# Altium schematic sheet SSL_Brushless_V3.0.0-Conn.SchDoc(SSL_Brushless_V3.0.0-Conn)
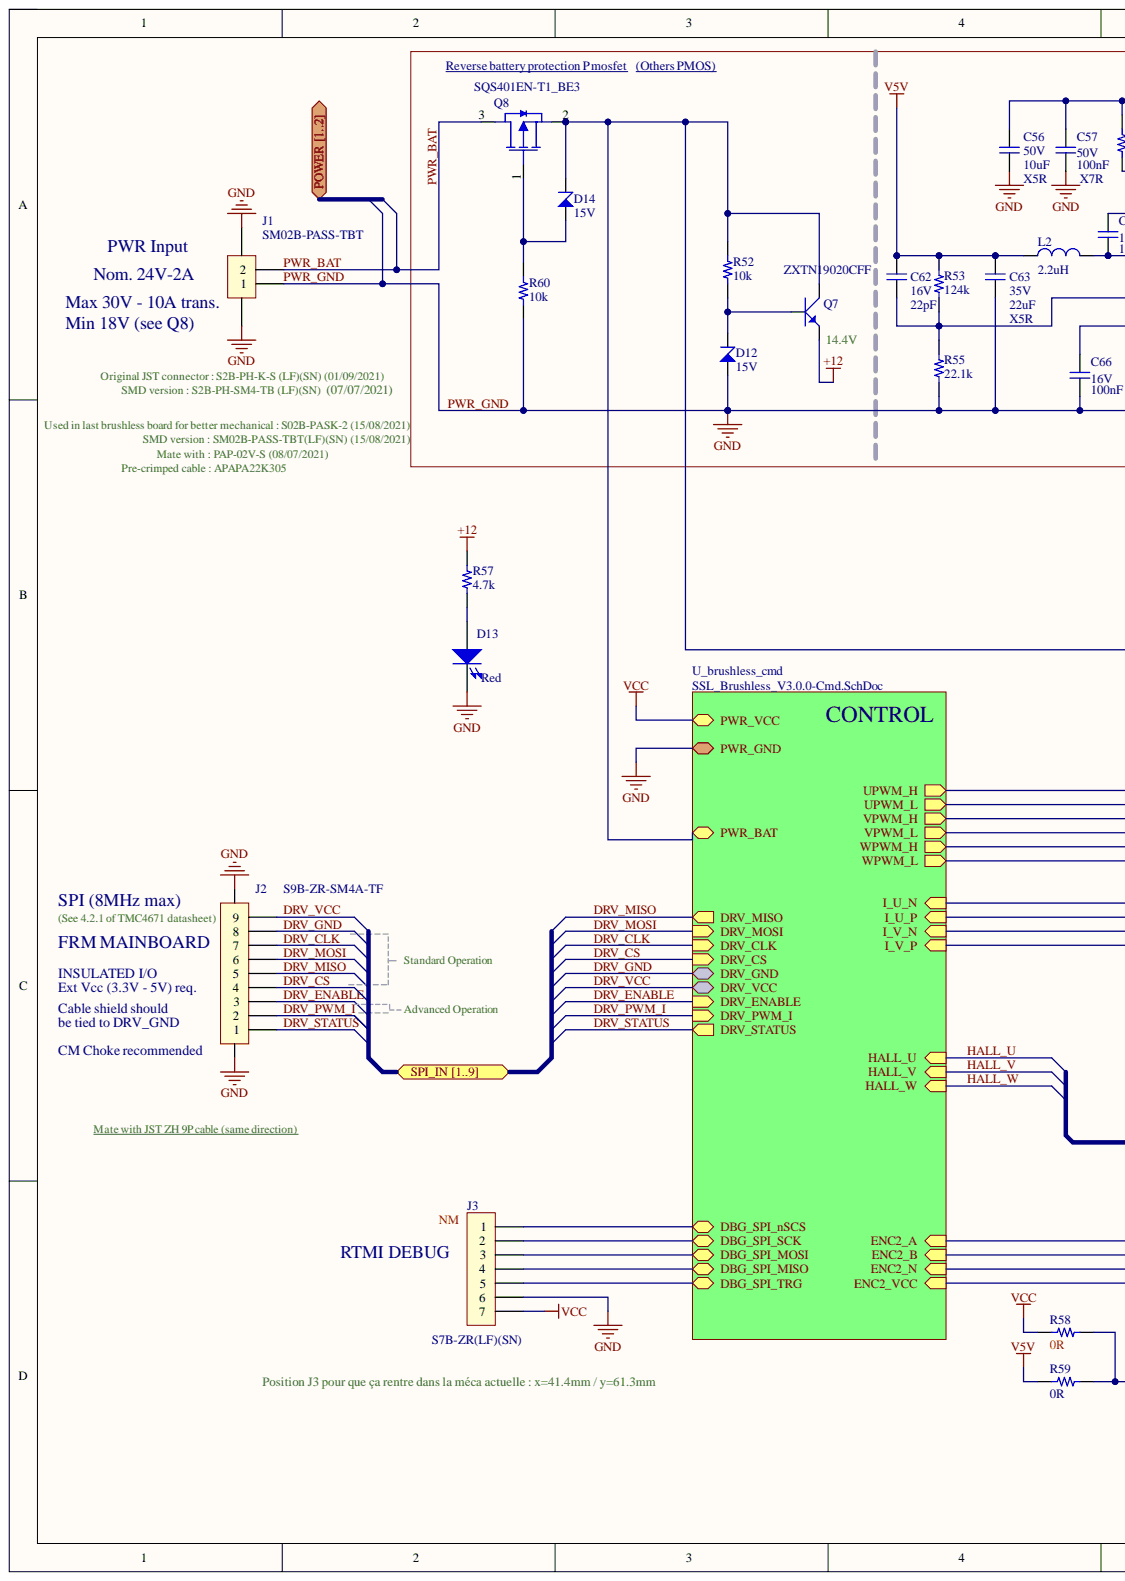
[diagram p0: SSL_Brushless_V3.0.0-Conn.SchDoc, left]
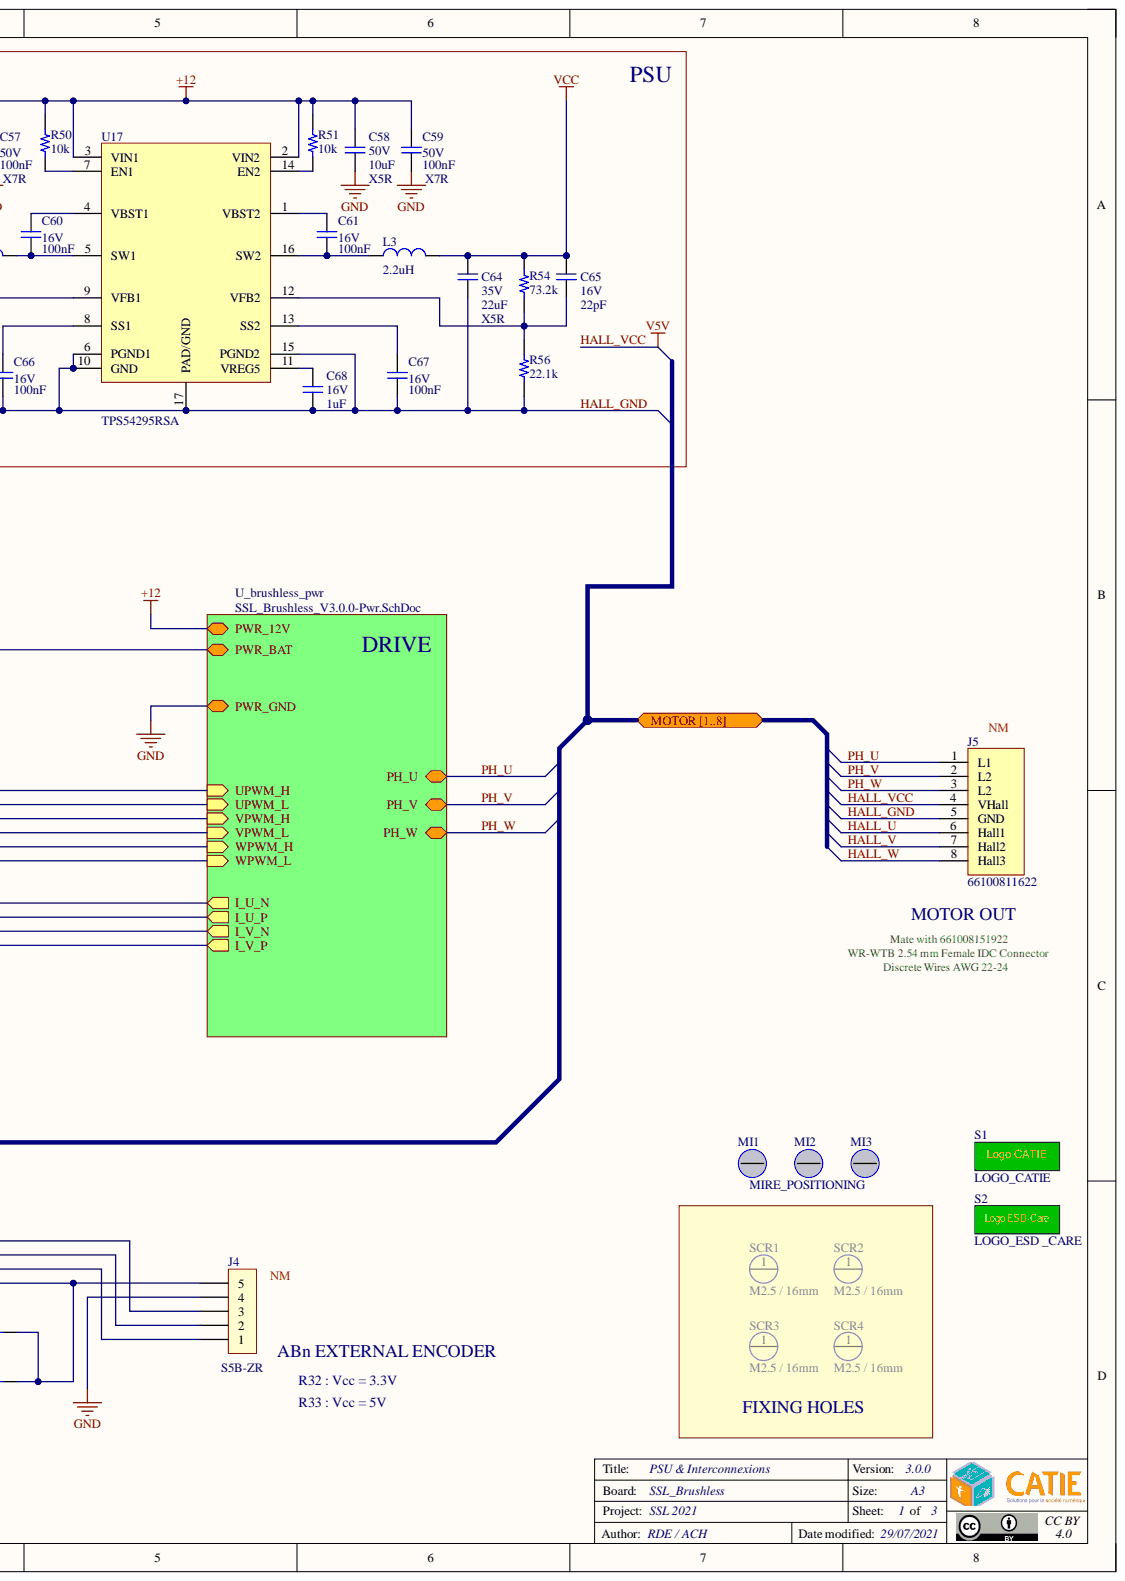
[diagram p1: SSL_Brushless_V3.0.0-Conn.SchDoc, right]

## Parts
C56  value 10uF  Ceram: X5R  Rating: 50V  [CAP_CER_1206]  (hidden comment: CAPA_CER_1206)
C57  value 100nF  Ceram: X7R  Rating: 50V  [CAP_CER_0402]  (hidden comment: CAP_CER_0402)
C58  value 10uF  Ceram: X5R  Rating: 50V  [CAP_CER_1206]  (hidden comment: CAPA_CER_1206)
C59  value 100nF  Ceram: X7R  Rating: 50V  [CAP_CER_0402]  (hidden comment: CAP_CER_0402)
C60  value 100nF  Rating: 16V  [CAP_CER_0402]  (hidden comment: CAP_CER_0402)
C61  value 100nF  Rating: 16V  [CAP_CER_0402]  (hidden comment: CAP_CER_0402)
C62  value 22pF  Rating: 16V  [CAP_CER_0402]  (hidden comment: CAP_CER_0402)
C63  value 22uF  Ceram: X5R  Rating: 35V  [CAP_CER_1206]  (hidden comment: CAPA_CER_1206)
C64  value 22uF  Ceram: X5R  Rating: 35V  [CAP_CER_1206]  (hidden comment: CAPA_CER_1206)
C65  value 22pF  Rating: 16V  [CAP_CER_0402]  (hidden comment: CAP_CER_0402)
C66  value 100nF  Rating: 16V  [CAP_CER_0402]  (hidden comment: CAP_CER_0402)
C67  value 100nF  Rating: 16V  [CAP_CER_0402]  (hidden comment: CAP_CER_0402)
C68  value 1uF  Rating: 16V  [CAP_CER_0402]  (hidden comment: CAP_CER_0402)
D12  value 15V  [DIODE_ZENER_BZD27C-SERIES_DO219-AB]  (hidden comment: DIODE_ZENER_BZD27C-SERIES_DO219-AB)
D13  Colour: Red  [DIODE_LED_SIDE-VIEW_1204_WE_155124XX73200]  (hidden comment: LED side view 1204)
D14  value 15V  [DIODE_ZENER_BZD27C-SERIES_DO219-AB]  (hidden comment: DIODE_ZENER_BZD27C-SERIES_DO219-AB)
J1  SM02B-PASS-TBT  [HEADER_JST_SM02B-PASS-TBT]
J2  Reference: S9B-ZR-SM4A-TF  [HEADER_JST_S9B-ZR-SM4A-TF_PITCH-1.5MM_9-PIN]  (hidden comment: HEADER_JST_S9B-ZR-SM4A-TF)
J3  S7B-ZR(LF)(SN)  value 7  Mount: NM  [HEADER_JST_S7B-ZR]
J4  S5B-ZR  Mount: NM  [HEADER_JST_S5B-ZR]
J5  66100811622  Mount: NM  [66100811622]
L2  value 2.2uH  [IND_CER_SRP2512A]  (hidden comment: SRP2512A-2R2M)
L3  value 2.2uH  [IND_CER_SRP2512A]  (hidden comment: SRP2512A-2R2M)
MI1  MIRE_POSITIONING  [MIRE_POSITIONING]  (no BOM)
MI2  MIRE_POSITIONING  [MIRE_POSITIONING]  (no BOM)
MI3  MIRE_POSITIONING  [MIRE_POSITIONING]  (no BOM)
Q7  Reference: ZXTN19020CFF  [TR_SOT23]  (hidden comment: SOT23F NPN Trnsistor)
Q8  SQS401EN-T1_BE3  [TR_PMOS_SQS401EN-T1BE3_POWERPAK-1212-8]
R50  value 10k  [RES_0402]  (hidden comment: RES_0402)
R51  value 10k  [RES_0402]  (hidden comment: RES_0402)
R52  value 10k  [RES_0603]  (hidden comment: RES_0603)
R53  value 124k  [RES_0402]  (hidden comment: RES_0402)
R54  value 73.2k  [RES_0402]  (hidden comment: RES_0402)
R55  value 22.1k  [RES_0402]  (hidden comment: RES_0402)
R56  value 22.1k  [RES_0402]  (hidden comment: RES_0402)
R57  value 4.7k  [RES_0402]  (hidden comment: RES_0402)
R58  value 0R  [RES_0603]  (hidden comment: RES_0603)
R59  value 0R  [RES_0603]  (hidden comment: RES_0603)
R60  value 10k  [RES_0603]  (hidden comment: RES_0603)
S1  LOGO_CATIE  Reference: CATIE  Type: Logo  [LOGO_CATIE]  (no BOM)
S2  LOGO_ESD _CARE  Type: Logo  [LOGO_ESD _CARE]  (no BOM)
SCR1  value M2.5 / 16mm  [SPACER_M2.5_SW5.5_L16_MF_METAL_INV_TOP]  (hidden comment: Spacer M3 SW5.5 L16 MF Metal Inv)
SCR2  value M2.5 / 16mm  [SPACER_M2.5_SW5.5_L16_MF_METAL_INV_TOP]  (hidden comment: Spacer M3 SW5.5 L16 MF Metal Inv)
SCR3  value M2.5 / 16mm  [SPACER_M2.5_SW5.5_L16_MF_METAL_INV_TOP]  (hidden comment: Spacer M3 SW5.5 L16 MF Metal Inv)
SCR4  value M2.5 / 16mm  [SPACER_M2.5_SW5.5_L16_MF_METAL_INV_TOP]  (hidden comment: Spacer M3 SW5.5 L16 MF Metal Inv)
U17  TPS54295RSA  [IC_TPS54295RSA_VQFN16]

## Ports
MOTOR 1, MOTOR 2, MOTOR 3, MOTOR 4, MOTOR 5, MOTOR 6, MOTOR 7, MOTOR 8, MOTOR [1..8], POWER 1, POWER 2, POWER [1..2], SPI_IN 1, SPI_IN 2, SPI_IN 3, SPI_IN 4, SPI_IN 5, SPI_IN 6, SPI_IN 7, SPI_IN 8, SPI_IN 9, SPI_IN [1..9]

## Nets
+12: C56.2 C57.1 C58.2 C59.1 Q7.2 R50.1 R51.1 R57.1 U17.2(VIN2) U17.3(VIN1)
VCC: C64.2 C65.2 J3.7 L3.2 R54.2 R58.2
DRV_CLK: J2.7
DRV_CS: J2.4
DRV_ENABLE: J2.3
DRV_GND: J2.8
DRV_MISO: J2.5
DRV_MOSI: J2.6
DRV_PWM_I: J2.2
DRV_STATUS: J2.1
DRV_VCC: J2.9
HALL_GND: C56.1 C57.2 C58.1 C59.2 C63.1 C64.1 C66.2 C67.2 C68.2 D12.2 D13.1 J1.1 J1.3 J1.4 J2.10 J2.11 J3.6 J4.4 J5.5(GND) R55.1 R56.1 R60.1 U17.6(PGND1) U17.10(GND) U17.15(PGND2) U17.17(PAD/GND)
HALL_U: J5.6(Hall1)
HALL_V: J5.7(Hall2)
HALL_VCC: C62.2 C63.2 J5.4(VHall) L2.1 R53.2 R59.2
HALL_W: J5.8(Hall3)
PH_U: J5.1(L1)
PH_V: J5.2(L2)
PH_W: J5.3(L2)
PWR_BAT: J1.2 Q8.3
NetC60_1: C60.1 U17.4(VBST1)
NetC60_2: C60.2 L2.2 U17.5(SW1)
NetC61_1: C61.1 U17.1(VBST2)
NetC61_2: C61.2 L3.1 U17.16(SW2)
NetC62_1: C62.1 R53.1 R55.2 U17.9(VFB1)
NetC65_1: C65.1 R54.1 R56.2 U17.12(VFB2)
NetC66_1: C66.1 U17.8(SS1)
NetC67_1: C67.1 U17.13(SS2)
NetC68_1: C68.1 U17.11(VREG5)
NetD12_1: D12.1 Q7.1 R52.1
NetD13_2: D13.2 R57.2
NetD14_1: D14.1 Q7.3 Q8.2 R52.2
NetD14_2: D14.2 Q8.1 R60.2
NetJ3_1: J3.1
NetJ3_2: J3.2
NetJ3_3: J3.3
NetJ3_4: J3.4
NetJ3_5: J3.5
NetJ4_1: J4.1
NetJ4_2: J4.2
NetJ4_3: J4.3
NetJ4_5: J4.5 R58.1 R59.1
NetMI1_1: MI1.1
NetMI2_1: MI2.1
NetMI3_1: MI3.1
NetR50_2: R50.2 U17.7(EN1)
NetR51_2: R51.2 U17.14(EN2)
NetSCR1_1: SCR1.1
NetSCR2_1: SCR2.1
NetSCR3_1: SCR3.1
NetSCR4_1: SCR4.1
I_U_N:
I_U_P:
I_V_N:
I_V_P:
N00001_1: ; port MOTOR [1..8]
N00001_2: ; port MOTOR [1..8]
N00001_3: ; port MOTOR [1..8]
N00001_4: ; port MOTOR [1..8]
N00001_5: ; port MOTOR [1..8]
N00001_6: ; port MOTOR [1..8]
N00001_7: ; port MOTOR [1..8]
N00001_8: ; port MOTOR [1..8]
N00002_1: ; port POWER [1..2]
N00002_2: ; port POWER [1..2]
N00003_1: ; port SPI_IN [1..9]
N00003_2: ; port SPI_IN [1..9]
N00003_3: ; port SPI_IN [1..9]
N00003_4: ; port SPI_IN [1..9]
N00003_5: ; port SPI_IN [1..9]
N00003_6: ; port SPI_IN [1..9]
N00003_7: ; port SPI_IN [1..9]
N00003_8: ; port SPI_IN [1..9]
N00003_9: ; port SPI_IN [1..9]
UPWM_H:
UPWM_L:
VPWM_H:
VPWM_L:
WPWM_H:
WPWM_L:

## Library data of the parts
C56 C58 = CAP_CER_1206: Capacitor ceramic 10uF 10% X7R 50V; Manufacturer: Samsung Electro-Mechanics; Reference: CL31A106MBHNNNE; Tol: 20%; Case: 1206; Component Kind: Standard; Library Name: Schematic_Components.SchLib; Mount: Mnt; Technology: CMS; Type: Capacitor
C57 C59 = CAP_CER_0402: Capacitor ceramic 100nF 10% X7R 50V; Manufacturer: Murata Electronics; Reference: GRM155R71H104KE14D; Case: 0402; Component Kind: Standard; ComponentLink1Description: Farnell; ComponentLink1URL: https://fr.farnell.com/tdk/cga2b3x7r1e104k050bb/condensateur-0-1-f-25v-10-x7r/dp/2210820; Library Name: Schematic_Components.SchLib; Mount: Mnt; Technology: CMS; Tol: 10%; Type: Capacitor
C60 C61 C66 C67 = CAP_CER_0402: Capacitor ceramic 100nF 10% X7R 16V; Manufacturer: TDK; Reference: C1005X7R1C104K050BC; Case: 0402; Ceram: X7R; Component Kind: Standard; ComponentLink1Description: Farnell; ComponentLink1URL: https://fr.farnell.com/tdk/cga2b3x7r1e104k050bb/condensateur-0-1-f-25v-10-x7r/dp/2210820; Library Name: Schematic_Components.SchLib; Mount: Mnt; Technology: CMS; Tol: 10%; Type: Capacitor
C62 C65 = CAP_CER_0402: Capacitor ceramic 22pF 10% X7R 16V; Manufacturer: KEMET; Reference: C0402C220K4RACTU; Case: 0402; Ceram: X7R; Component Kind: Standard; Library Name: Schematic_Components.SchLib; Mount: Mnt; Technology: CMS; Tol: 10%; Type: Capacitor
C63 C64 = CAP_CER_1206: Capacitor ceramic 22uF 20% X5R 35V; Manufacturer: TDK; Reference: C3216X5R1V226M160AC; Tol: 10%; Case: 1206; Component Kind: Standard; Library Name: Schematic_Components.SchLib; Mount: Mnt; Technology: CMS; Type: Capacitor
  C63: ComponentLink1Description: Farnell; ComponentLink1URL: https://fr.farnell.com/avx/1206yd226kat2a/condensateur-22-f-16v-10-x5r-1206/dp/1833822
  C64: ComponentLink1Description: Digikey; ComponentLink1URL: https://www.digikey.fr/product-detail/fr/murata-electronics/GRT31CC81C226KE01L/490-12409-1-ND/5417110
C68 = CAP_CER_0402: Capacitor ceramic 1uF 10% X6S 16V; Manufacturer: KEMET; Ceram: X5R; Reference: C0402C105K4PAC7867; Case: 0402; Component Kind: Standard; Library Name: Schematic_Components.SchLib; Mount: Mnt; Technology: CMS; Tol: 10%; Type: Capacitor
D12 D14 = DIODE_ZENER_BZD27C-SERIES_DO219-AB: Diode Zener BZD27C-series DO219-AB case; Manufacturer: Vishay; Rating: 800mW; Reference: BZD27C15P-E3-08; Tol: 1%; Case: SOD132F; Component Kind: Standard; Library Name: Schlib1.SchLib; Mount: Mnt; Supplier 1: Digi-Key; Supplier Part Number 1: BZD27C15P-E3-08CT-ND; Technology: CMS; Type: Diode
D13 = DIODE_LED_SIDE-VIEW_1204_WE_155124XX73200: LED side view 1204 Red; Manufacturer: Wurth Elektronik; Manufacturer Part Number 1: 155124RS73200; Rating: 25mA; Value: 2V; Case: 1204; Component Kind: Standard; Library Name: Schematic_Components.SchLib; Manufacturer 1: Wurth Elektronik; Mount: Mnt; Reference: 155124RS73200; Supplier 1: Digi-key; Supplier Part Number 1: 732-5025-1-ND; Technology: CMS; Type: Diode_LED
J1 = HEADER_JST_SM02B-PASS-TBT: COMPONENT DESCRIPTION PTION; Manufacturer: JST; Value: 2 pins; Case: 8.5*8*5.7; Component Kind: Standard; Mount: mnt; MSL: 1; Reference: SM02B-PASS-TBT; Technology: CMS; Temperature: -25°C / +85°C; Type: HEADER; Library Name: SSL_Brushless.SchLib
J2 = HEADER_JST_S9B-ZR-SM4A-TF: HEADER_JST_S9B-ZR-SM4A-TF_PITCH-1.5MM_9-PIN; Manufacturer: JST; Contacts: SZH-002T-P0.5; Female housing: ZHR-6; Pre-crimped cable 150mm: 01SZHSZH-30L-150; Pre-crimped cable 300mm: 01SZHSZH-30L-300; Rating: 1A / 50V; Value: 20mR; Component Kind: Standard; Library Name: Schlib1.SchLib; Mount: Mnt; Supplier 1: Digi-Key; Supplier Part Number 1: 455-1610-1-ND; Technology: CMS; Type: Header
J3 = HEADER_JST_S7B-ZR: CONN HEADER R/A 7POS 1.5MM; Manufacturer: JST; Case: 6x12x3.7; Component Kind: Standard; MSL: 1; Reference: S7B-ZR(LF)(SN); Technology: TRAD; Temperature: -25°C / +85°C; Type: HEADER; Library Name: SSL_Brushless.SchLib
J4 = HEADER_JST_S5B-ZR: HEADER_JST_S5B-ZR_PITCH-1.5MM_5-PIN; Manufacturer: JST; Contacts: SZH-002T-P0.5; Female housing: ZHR-6; Pre-crimped cable 150mm: 01SZHSZH-30L-150; Pre-crimped cable 300mm: 01SZHSZH-30L-300; Rating: 1A / 50V; Value: 20mR; Component Kind: Standard; Reference: S5B-ZR; Supplier 1: Digi-Key; Supplier Part Number 1: 455-1610-1-ND; Technology: CMS; Type: Header; Library Name: SSL_Brushless.SchLib
J5 = MOTOR_CON_EC397172_V2: Header 8-pin 1row; Manufacturer: Wurth Elektronik; Female housing: 661008151922; Component Kind: Standard; Library Name: Schlib1.SchLib; Reference: 66100811622; Technology: TRAD; Type: Header
L2 L3 = IND_CER_2512: Ceramic Inductor 2.2uH; Manufacturer: Bourns; Type: IND; Case: 2.5mm x 2.0mm; Component Kind: Standard; Mount: mnt; MSL: 1; Reference: SRP2512A-2R2M; Technology: CMS; Temperature: -??°C / +??°C; Library Name: SSL_Brushless.SchLib
MI1-MI3 = MIRE_POSITIONING: Mire de positionnement; Mount: NM; Library Name: Schematic_Components.SchLib; Type: Meca
Q7 = Q_NPN: General purpose bipolar NPN transistor; Manufacturer: Diode Inc; Case: SOT-23; Component Kind: Standard; Library Name: Schlib1.SchLib; Mount: Mnt; Technology: CMS; Type: Transistor
Q8 = TR_PMOS_SQS401EN-T1BE3_POWERPAK-1212-8: MOSFET P-CH 40V 16A PPAK1212-8; Manufacturer: Vishay; Rating: 29mOhms; Tol: 62.5W (Tc); Type: Transistor; Value: 40V 16A; Case: PPAK1212-8; Component Kind: Standard; Mount: mnt; MSL: 1; Reference: SQS401EN-T1_BE3; Technology: CMS; Temperature: -55°C / +175°C; Library Name: SSL_Brushless.SchLib
R50 R51 = RES_0402: Resistor 10k 1%; Manufacturer: Yageo; Reference: RC0402JR-0710KL; Tol: 5%; Case: 0402; Component Kind: Standard; Library Name: Schematic_Components.SchLib; Mount: Mnt; Technology: CMS; Type: Resistor
R52 R60 = RES_0603: RES SMD 10K OHM 1% 1/10W 0603; Manufacturer: Yageo; Rating: 0.1W; Reference: RC0603FR-0710KL; Case: 0603; Component Kind: Standard; Library Name: Schematic_Components.SchLib; Mount: Mnt; Technology: CMS; Tol: 1%; Type: Resistor
R53 = RES_0402: Resistor 124k 1%; Manufacturer: Yageo; Rating: 1/16W; Reference: RC0402FR-07124KL; Case: 0402; Component Kind: Standard; Library Name: Schematic_Components.SchLib; Mount: Mnt; Technology: CMS; Tol: 1%; Type: Resistor
R54 = RES_0402: Resistor 73.2k 1%; Manufacturer: Yageo; Rating: 1/16W; Reference: RC0402FR-0773K2L; Case: 0402; Component Kind: Standard; Library Name: Schematic_Components.SchLib; Mount: Mnt; Technology: CMS; Tol: 1%; Type: Resistor
R55 R56 = RES_0402: RES SMD 22.1K OHM 1% 1/16W 0402; Manufacturer: Vishay Dale; Reference: CRCW040222K1FKEDC; Case: 0402; Component Kind: Standard; Library Name: Schematic_Components.SchLib; Mount: Mnt; Rating: 1/16W; Technology: CMS; Tol: 1%; Type: Resistor
R57 = RES_0402: RES SMD 4.7K OHM 1% 1/16W 0402; Manufacturer: Yageo; Reference: AC0402FR-074K7L; Case: 0402; Component Kind: Standard; Library Name: Schematic_Components.SchLib; Mount: Mnt; Technology: CMS; Type: Resistor
R58 = RES_0603: Resistor SMD 0603; Manufacturer: Yageo; Mount: NM; Rating: 1/10W; Reference: RC0603FR-070RL; Tol: 1%; Case: 0603; Component Kind: Standard; Library Name: Schematic_Components.SchLib; Technology: CMS; Type: Resistor
R59 = RES_0603: Resistor SMD 0603; Manufacturer: Yageo; Rating: 1/10W; Reference: RC0603FR-070RL; Tol: 1%; Case: 0603; Component Kind: Standard; Library Name: Schematic_Components.SchLib; Mount: Mnt; Technology: CMS; Type: Resistor
S1 = LOGO_CATIE: Logo CATIE Full Silkscreen; Mount: NM; Library Name: Schematic_Components.SchLib
S2 = LOGO_ESD _CARE: Logo ESD Care Silkscreen simplified; Mount: NM; Library Name: Schematic_Components.SchLib; Reference: ESD
SCR1-SCR4 = SPACER_M2.5_SW5.5_L16_MF_METAL_INV_TOP: Spacer M3 16mm long male-female metal inverted Top; Manufacturer: Wurth Elektronik; Mount: NM; Reference: 971160321; Component Kind: Standard; Library Name: Schlib1.SchLib; Technology: TRAD; Type: Meca
  SCR2: Supplier 1: Digi-Key; Supplier Part Number 1: 732-10423-ND
U17 = IC_TPS54295RSA_VQFN16: Dual Channel Synchronous Step-Down Buck 2A/2A ; 4.5V to 18V input.; Manufacturer: Texas Instruments; Value: 2A; Case: VQFN-16; Component Kind: Standard; Mount: mnt; MSL: 2; Rating: 4.5V to 18V; Reference: TPS54295RSA; Technology: CMS; Temperature: -??°C / +??°C; Type: IC; Library Name: SSL_Brushless.SchLib
